# Altium schematic sheet STM32F407IGT6.SchDoc
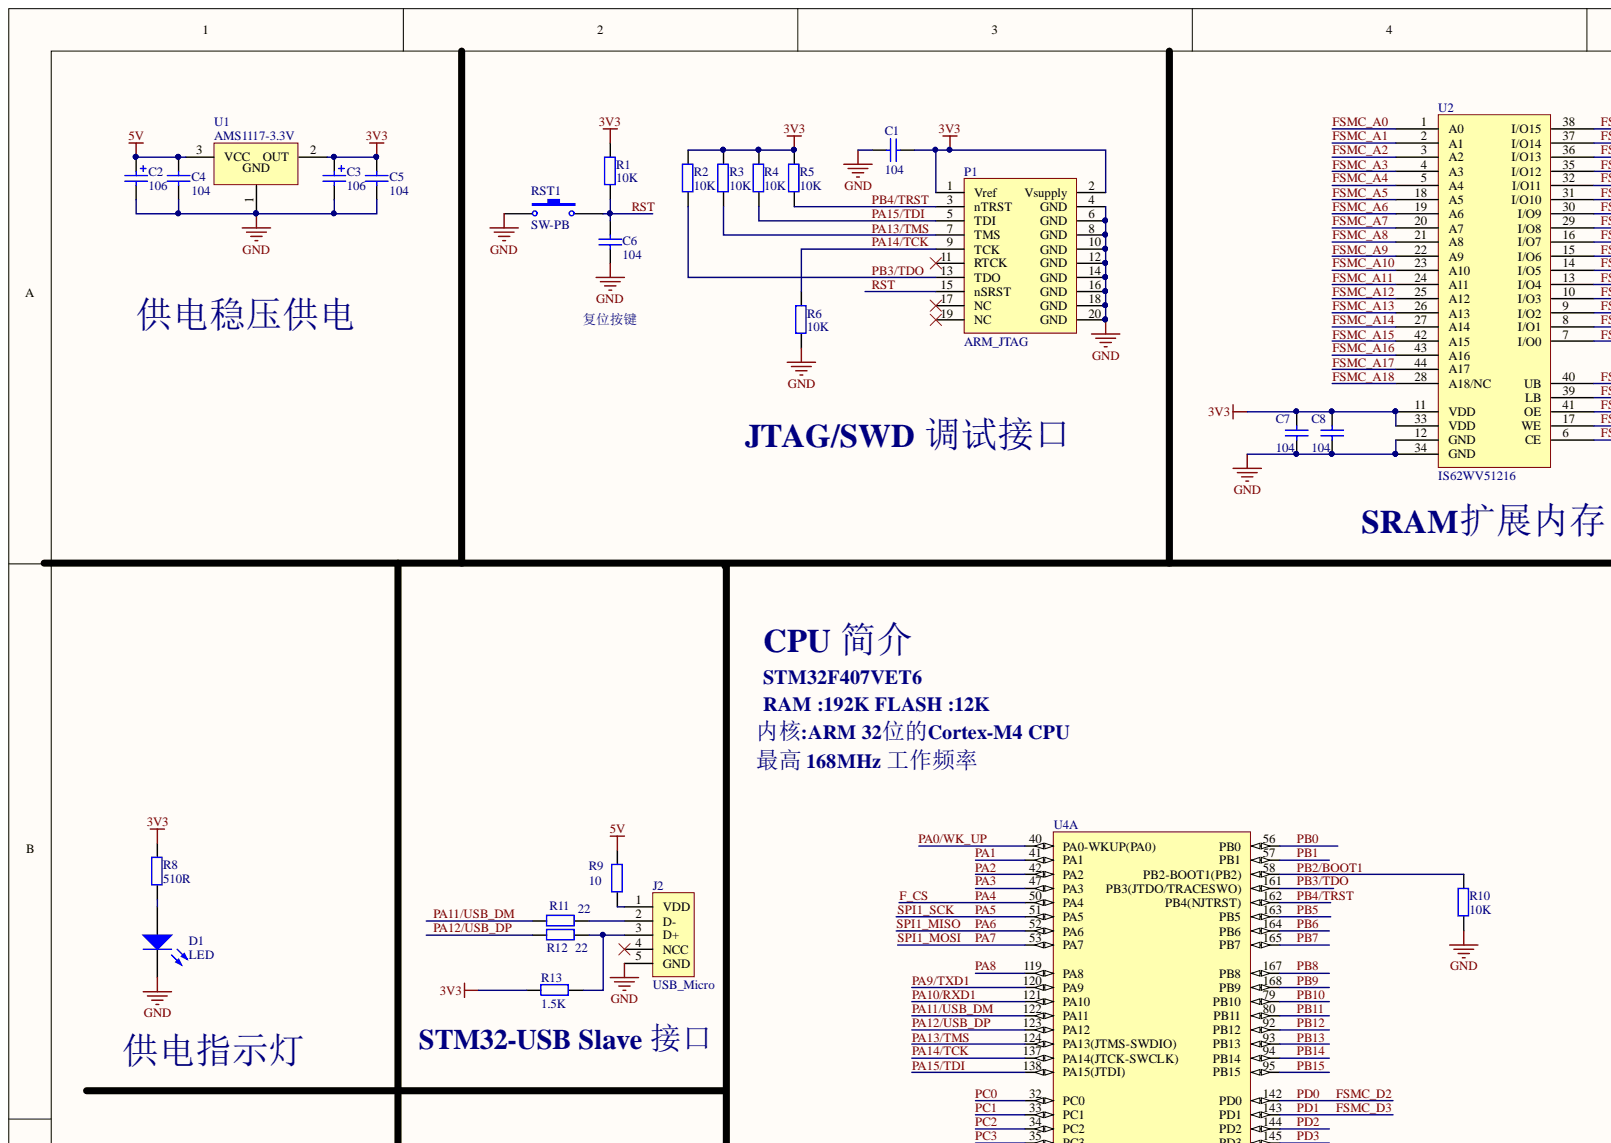
[diagram p0: STM32F407IGT6.SchDoc, top left]
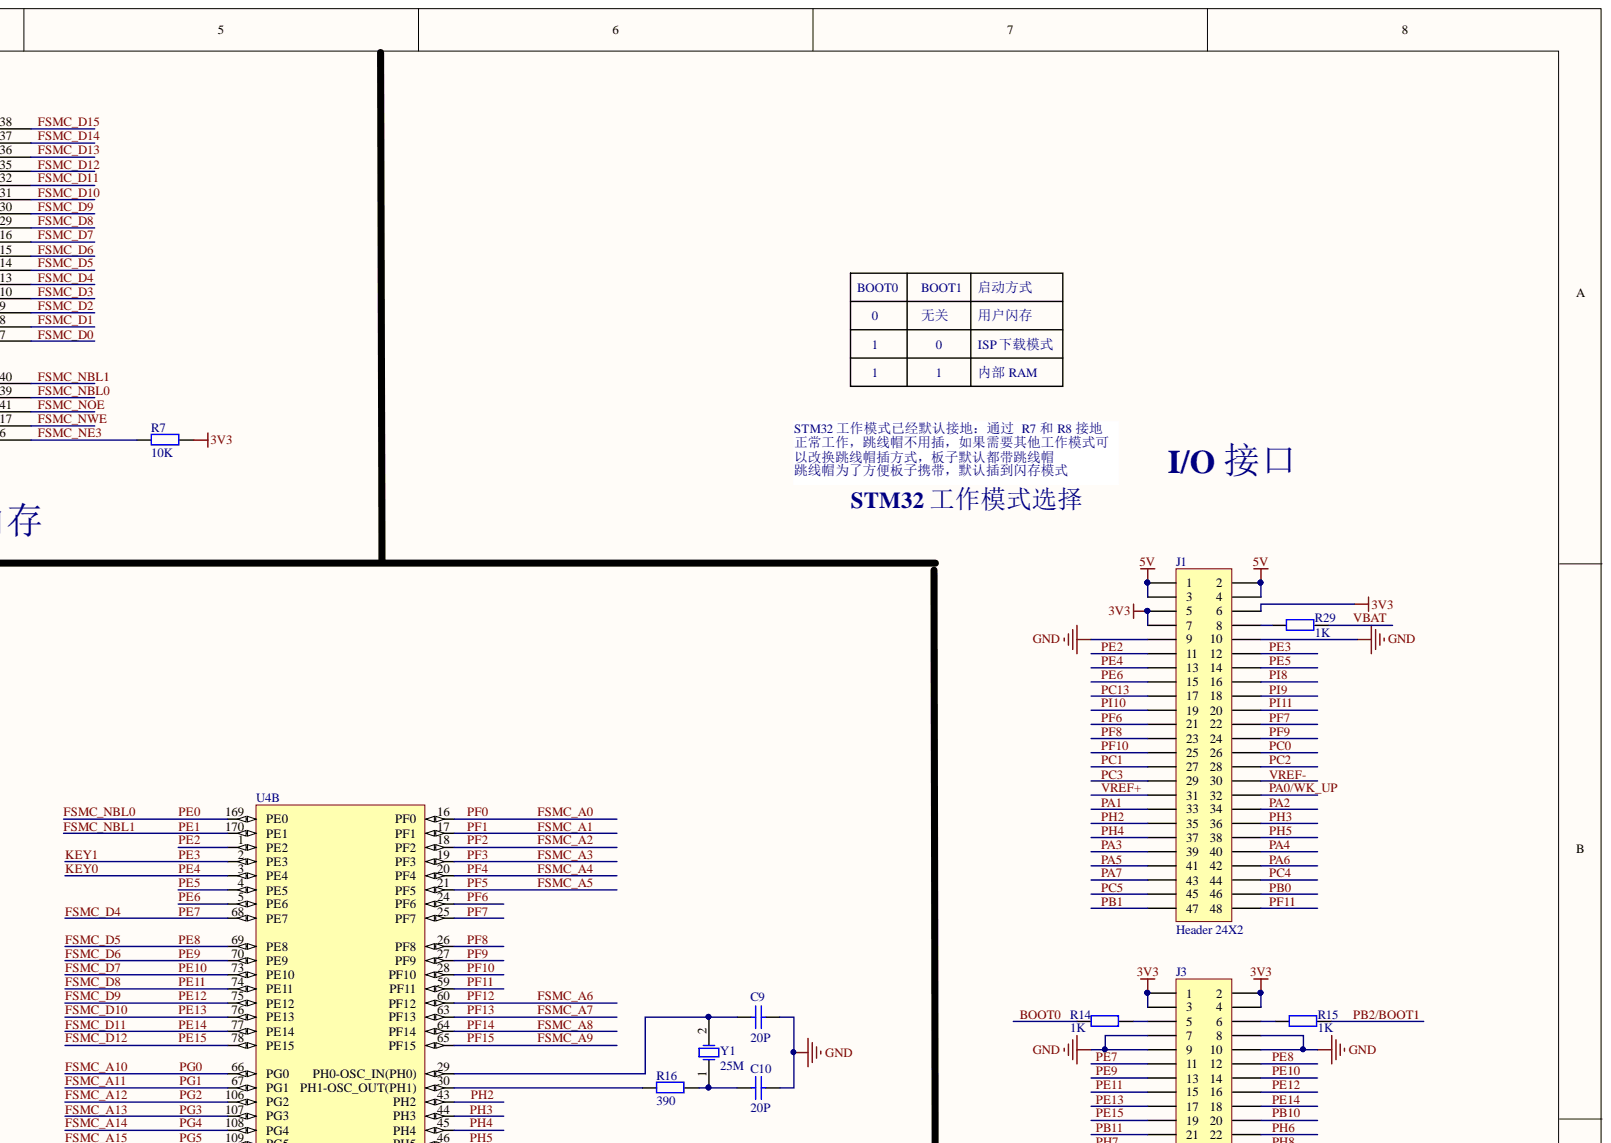
[diagram p1: STM32F407IGT6.SchDoc, top right]
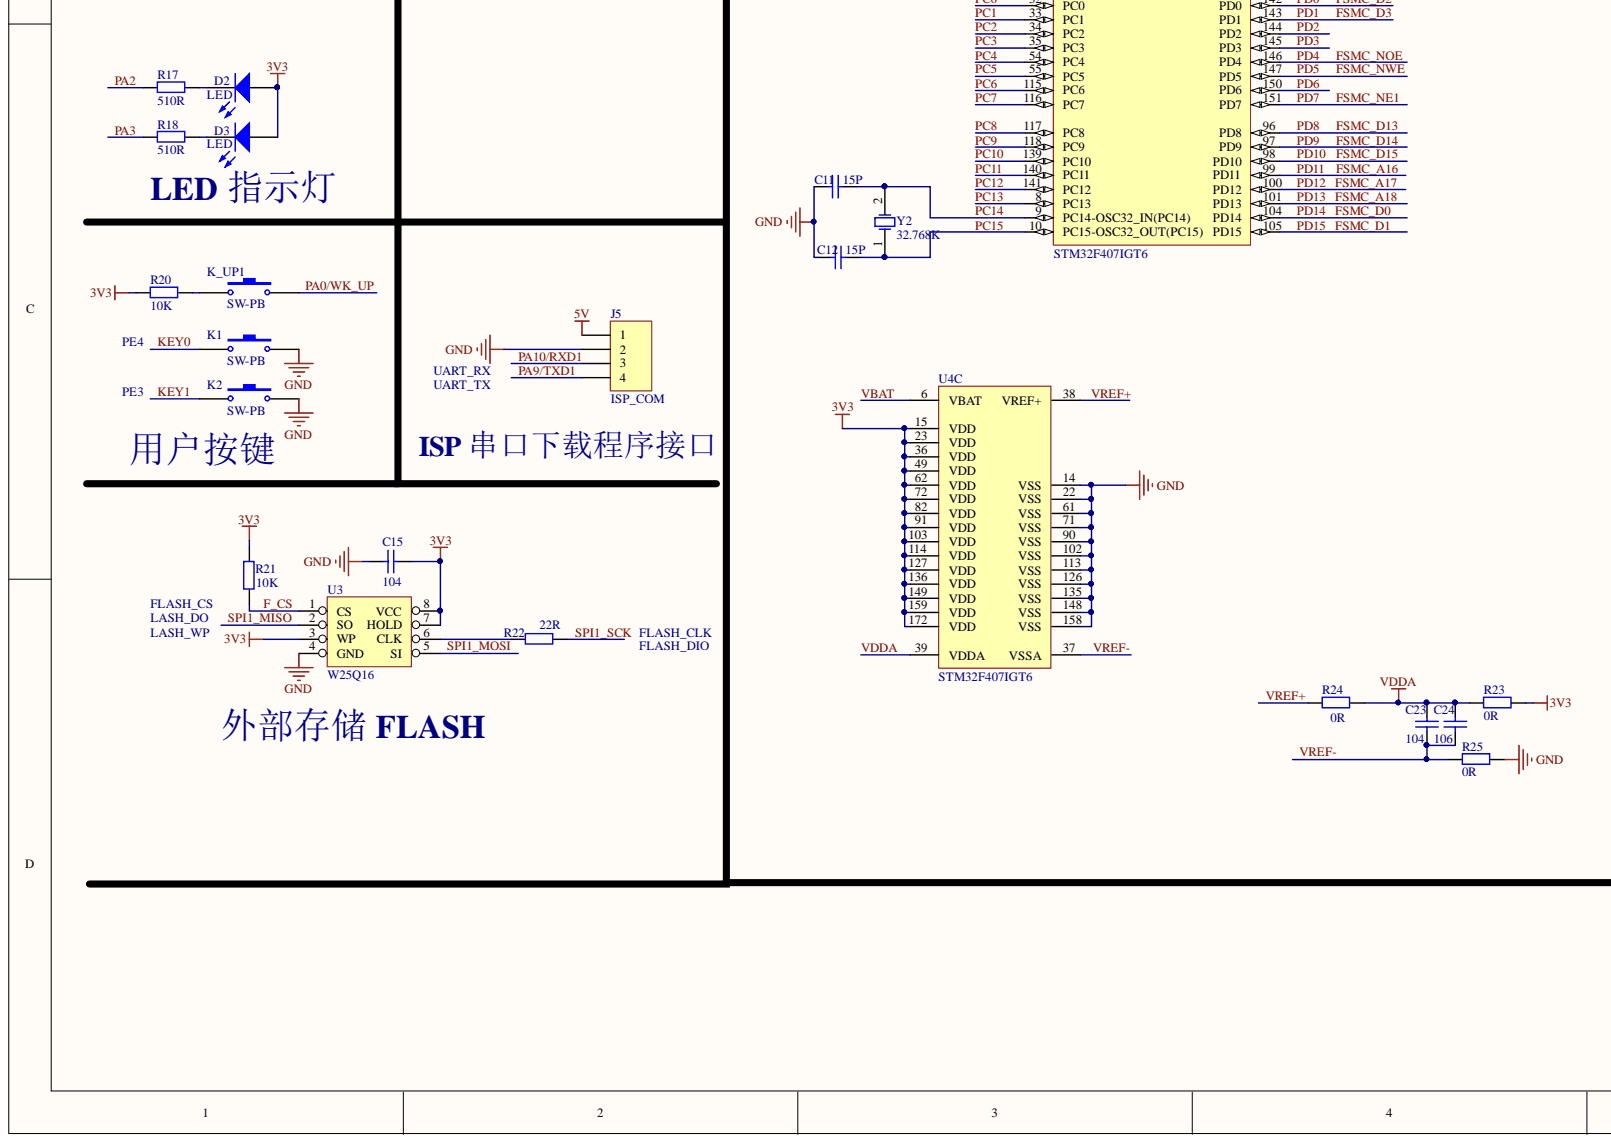
[diagram p2: STM32F407IGT6.SchDoc, bottom left]
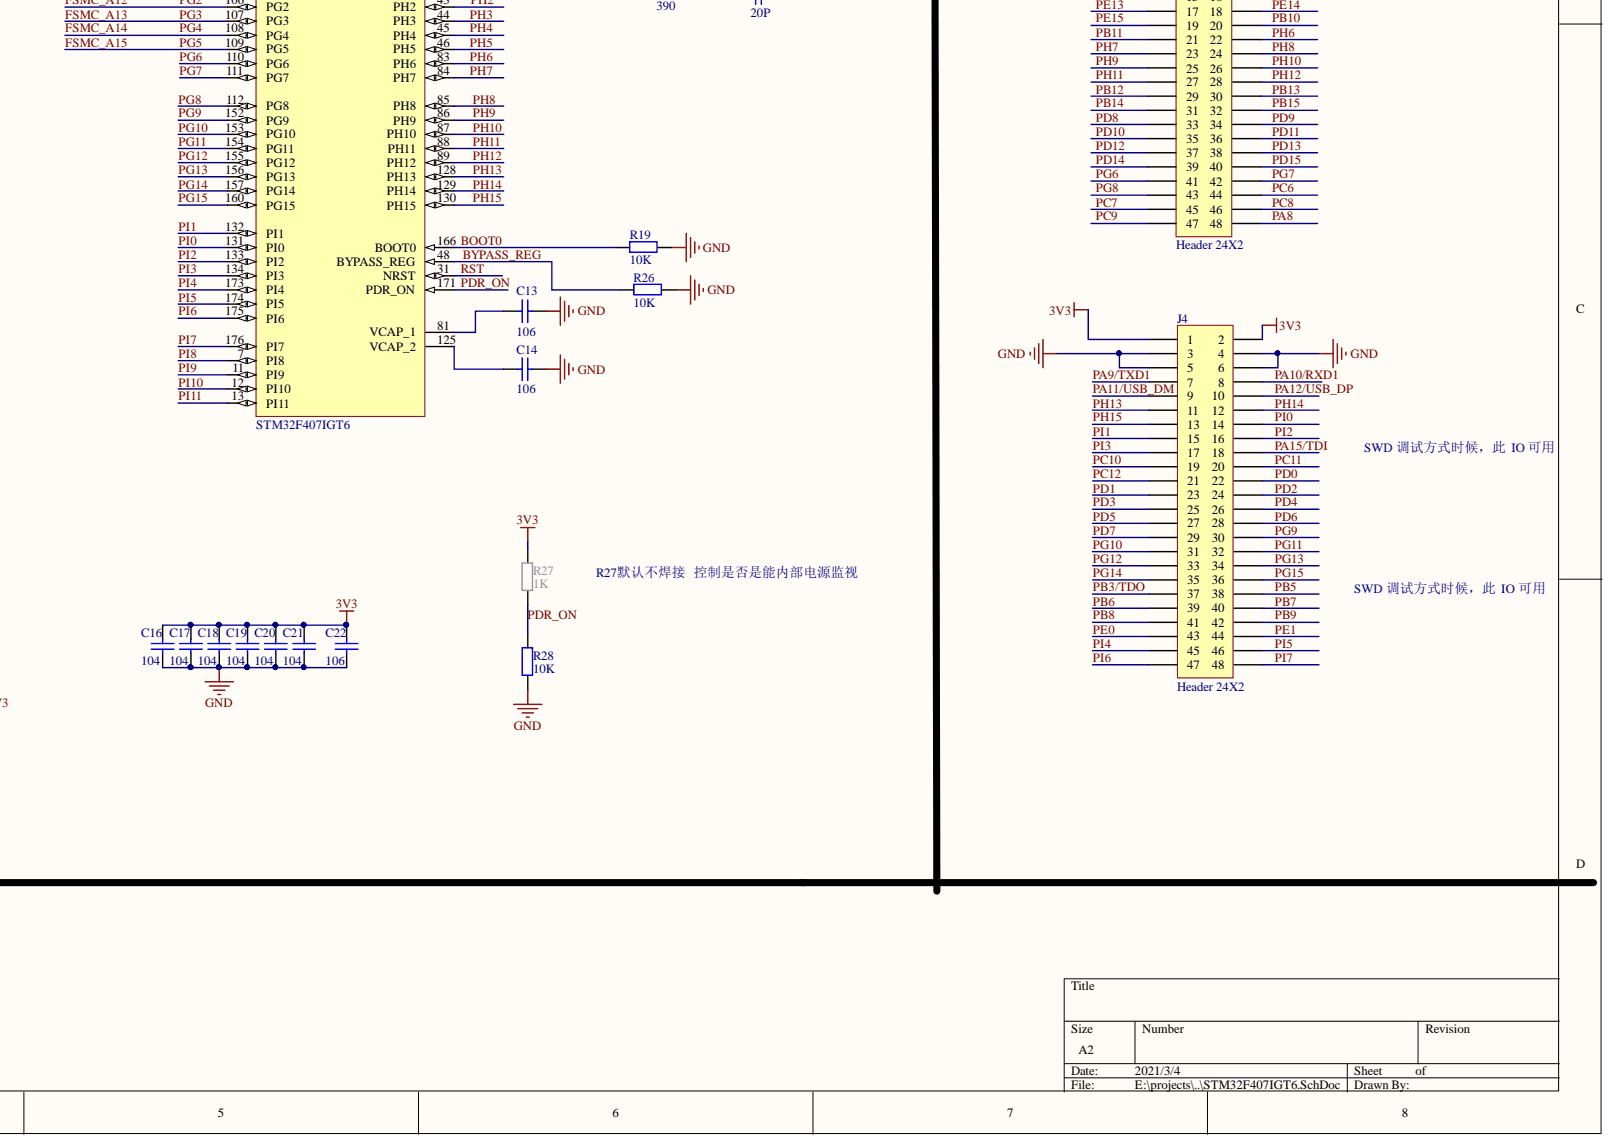
[diagram p3: STM32F407IGT6.SchDoc, bottom right]

## Parts
C1  104  [0603_c]
C2  106  [0603_c]
C3  106  [0603_c]
C4  104  [0603_c]
C5  104  [0603_c]
C6  104  [0603_c]
C7  104  [0603_c]
C8  104  [0603_c]
C9  20P  [0603_c]
C10  20P  [0603_c]
C11  15P  [0603_c]
C12  15P  [0603_c]
C13  106  [0603_c]
C14  106  [0603_c]
C15  104  [0603_c]
C16  104  [0603_c]
C17  104  [0603_c]
C18  104  [0603_c]
C19  104  [0603_c]
C20  104  [0603_c]
C21  104  [0603_c]
C22  106  [0603_c]
C23  104  [0603_c]
C24  106  [0603_c]
D1  LED  [LED0805]
D2  LED  [LED0805]
D3  LED  [LED0805]
J1  Header 24X2  [IDE2X24]
J2  USB_Micro  [USB_Micro]
J3  Header 24X2  [IDE2X24]
J4  Header 24X2  [IDE2X24]
J5  ISP_COM  [HDR1X4]
K1  SW-PB  [SW-SPST]
K2  SW-PB  [SW-SPST]
K_UP1  SW-PB  [SW-SPST]
P1  ARM_JTAG  [JTAG-20P]
R1  10K  [0603_r]
R2  10K  [0603_r]
R3  10K  [0603_r]
R4  10K  [0603_r]
R5  10K  [0603_r]
R6  10K  [0603_r]
R7  10K  [0603_r]
R8  510R  [0603_r]
R9  10  [0603_r]
R10  10K  [0603_r]
R11  22  [0603_r]
R12  22  [0603_r]
R13  1.5K  [0603_r]
R14  1K  [0603_r]
R15  1K  [0603_r]
R16  390  [0603_r]
R17  510R  [0603_r]
R18  510R  [0603_r]
R19  10K  [0603_r]
R20  10K  [0603_r]
R21  10K  [0603_r]
R22  22R  [0603_r]
R23  0R  [0603_r]
R24  0R  [0603_r]
R25  0R  [0603_r]
R26  10K  [0603_r]
R27  1K  [0603_r]
R28  10K  [0603_r]
R29  1K  [0603_r]
RST1  SW-PB  [SW-SPST]
U1  AMS1117-3.3V  [SOT-223]
U2  IS62WV51216  [IS62WV51216BLL-55TLI_ISI-M]
U3  W25Q16  [SOP8L]
U4  STM32F407IGT6  [STM-LQFP176_L]  (parts A,B,C)
Y1  25M  [49S_SMD]
Y2  32.768K  [3215_smd]

## Nets
3V3: C1.2 C3.1 C5.1 C7.1 C8.1 C15.2 C16.1 C17.1 C18.1 C19.1 C20.1 C21.1 C22.1 D2.1 D3.1 J1.5 J1.6 J1.7 J3.1 J3.2 J3.3 J3.4 J4.1 J4.2 P1.1(Vref) P1.2(Vsupply) R1.1 R2.1 R3.1 R4.1 R5.1 R7.1 R8.2 R13.2 R20.2 R21.1 R23.2 R27.2 U1.2 U2.11(VDD) U2.33(VDD) U3.3(WP) U3.7(HOLD) U3.8(VCC) U4.15(VDD) U4.23(VDD) U4.36(VDD) U4.49(VDD) U4.62(VDD) U4.72(VDD) U4.82(VDD) U4.91(VDD) U4.103(VDD) U4.114(VDD) U4.127(VDD) U4.136(VDD) U4.149(VDD) U4.159(VDD) U4.172(VDD)
5V: C2.1 C4.1 J1.1 J1.2 J1.3 J1.4 J5.1 R9.1 U1.3
GND: C1.1 C2.2 C3.2 C4.2 C5.2 C6.1 C7.2 C8.2 C9.2 C10.1 C11.1 C12.1 C13.1 C14.1 C15.1 C16.2 C17.2 C18.2 C19.2 C20.2 C21.2 C22.2 D1.2 J1.9 J1.10 J2.5(GND) J3.7 J3.8 J3.9 J3.10 J4.3 J4.4 J4.5 J4.6 J5.2 K1.2 K2.2 P1.4(GND) P1.6(GND) P1.8(GND) P1.10(GND) P1.12(GND) P1.14(GND) P1.16(GND) P1.18(GND) P1.20(GND) R6.1 R10.1 R19.1 R25.2 R26.1 R28.1 RST1.1 U1.1 U2.12(GND) U2.34(GND) U3.4(GND) U4.14(VSS) U4.22(VSS) U4.61(VSS) U4.71(VSS) U4.90(VSS) U4.102(VSS) U4.113(VSS) U4.126(VSS) U4.135(VSS) U4.148(VSS) U4.158(VSS)
BOOT0: R14.1 R19.2 U4.166(BOOT0)
BYPASS_REG: R26.2 U4.48(BYPASS_REG)
F_CS: J1.40 R21.2 U3.1(CS) U4.50(PA4)
FSMC_A0: U2.1(A0) U4.16(PF0)
FSMC_A1: U2.2(A1) U4.17(PF1)
FSMC_A2: U2.3(A2) U4.18(PF2)
FSMC_A3: U2.4(A3) U4.19(PF3)
FSMC_A4: U2.5(A4) U4.20(PF4)
FSMC_A5: U2.18(A5) U4.21(PF5)
FSMC_A6: U2.19(A6) U4.60(PF12)
FSMC_A7: U2.20(A7) U4.63(PF13)
FSMC_A8: U2.21(A8) U4.64(PF14)
FSMC_A9: U2.22(A9) U4.65(PF15)
FSMC_A10: U2.23(A10) U4.66(PG0)
FSMC_A11: U2.24(A11) U4.67(PG1)
FSMC_A12: U2.25(A12) U4.106(PG2)
FSMC_A13: U2.26(A13) U4.107(PG3)
FSMC_A14: U2.27(A14) U4.108(PG4)
FSMC_A15: U2.42(A15) U4.109(PG5)
FSMC_A16: J3.36 U2.43(A16) U4.99(PD11)
FSMC_A17: J3.37 U2.44(A17) U4.100(PD12)
FSMC_A18: J3.38 U2.28(A18/NC) U4.101(PD13)
FSMC_D0: J3.39 U2.7(I/O0) U4.104(PD14)
FSMC_D1: J3.40 U2.8(I/O1) U4.105(PD15)
FSMC_D2: J4.22 U2.9(I/O2) U4.142(PD0)
FSMC_D3: J4.23 U2.10(I/O3) U4.143(PD1)
FSMC_D4: J3.11 U2.13(I/O4) U4.68(PE7)
FSMC_D5: J3.12 U2.14(I/O5) U4.69(PE8)
FSMC_D6: J3.13 U2.15(I/O6) U4.70(PE9)
FSMC_D7: J3.14 U2.16(I/O7) U4.73(PE10)
FSMC_D8: J3.15 U2.29(I/O8) U4.74(PE11)
FSMC_D9: J3.16 U2.30(I/O9) U4.75(PE12)
FSMC_D10: J3.17 U2.31(I/O10) U4.76(PE13)
FSMC_D11: J3.18 U2.32(I/O11) U4.77(PE14)
FSMC_D12: J3.19 U2.35(I/O12) U4.78(PE15)
FSMC_D13: J3.33 U2.36(I/O13) U4.96(PD8)
FSMC_D14: J3.34 U2.37(I/O14) U4.97(PD9)
FSMC_D15: J3.35 U2.38(I/O15) U4.98(PD10)
FSMC_NBL0: J4.43 U2.39(LB) U4.169(PE0)
FSMC_NBL1: J4.44 U2.40(UB) U4.170(PE1)
FSMC_NE1: J4.29 U4.151(PD7)
FSMC_NE3: R7.2 U2.6(CE)
FSMC_NOE: J4.26 U2.41(OE) U4.146(PD4)
FSMC_NWE: J4.27 U2.17(WE) U4.147(PD5)
KEY0: J1.13 K1.1 U4.3(PE4)
KEY1: J1.12 K2.1 U4.2(PE3)
PA0/WK_UP: J1.32 K_UP1.2 U4.40(PA0-WKUP(PA0))
PA1: J1.33 U4.41(PA1)
PA2: J1.34 R17.1 U4.42(PA2)
PA3: J1.39 R18.1 U4.47(PA3)
PA5: J1.41 R22.2 U4.51(PA5)
PA6: J1.42 U3.2(SO) U4.52(PA6)
PA7: J1.43 U3.5(SI) U4.53(PA7)
PA8: J3.48 U4.119(PA8)
PA9/TXD1: J4.7 J5.4 U4.120(PA9)
PA10/RXD1: J4.8 J5.3 U4.121(PA10)
PA11/USB_DM: J4.9 R11.2 U4.122(PA11)
PA12/USB_DP: J4.10 R12.2 U4.123(PA12)
PA13/TMS: P1.7(TMS) R3.2 U4.124(PA13(JTMS-SWDIO))
PA14/TCK: P1.9(TCK) R6.2 U4.137(PA14(JTCK-SWCLK))
PA15/TDI: J4.18 P1.5(TDI) R4.2 U4.138(PA15(JTDI))
PB0: J1.46 U4.56(PB0)
PB1: J1.47 U4.57(PB1)
PB2/BOOT1: R10.2 R15.2 U4.58(PB2-BOOT1(PB2))
PB3/TDO: J4.37 P1.13(TDO) R2.2 U4.161(PB3(JTDO/TRACESWO))
PB4/TRST: P1.3(nTRST) R5.2 U4.162(PB4(NJTRST))
PB5: J4.38 U4.163(PB5)
PB6: J4.39 U4.164(PB6)
PB7: J4.40 U4.165(PB7)
PB8: J4.41 U4.167(PB8)
PB9: J4.42 U4.168(PB9)
PB10: J3.20 U4.79(PB10)
PB11: J3.21 U4.80(PB11)
PB12: J3.29 U4.92(PB12)
PB13: J3.30 U4.93(PB13)
PB14: J3.31 U4.94(PB14)
PB15: J3.32 U4.95(PB15)
PC0: J1.26 U4.32(PC0)
PC1: J1.27 U4.33(PC1)
PC2: J1.28 U4.34(PC2)
PC3: J1.29 U4.35(PC3)
PC4: J1.44 U4.54(PC4)
PC5: J1.45 U4.55(PC5)
PC6: J3.44 U4.115(PC6)
PC7: J3.45 U4.116(PC7)
PC8: J3.46 U4.117(PC8)
PC9: J3.47 U4.118(PC9)
PC10: J4.19 U4.139(PC10)
PC11: J4.20 U4.140(PC11)
PC12: J4.21 U4.141(PC12)
PC13: J1.17 U4.8(PC13)
PC14: C11.2 U4.9(PC14-OSC32_IN(PC14)) Y2.2
PC15: C12.2 U4.10(PC15-OSC32_OUT(PC15)) Y2.1
PD2: J4.24 U4.144(PD2)
PD3: J4.25 U4.145(PD3)
PD6: J4.28 U4.150(PD6)
PDR_ON: R27.1 R28.2 U4.171(PDR_ON)
PE2: J1.11 U4.1(PE2)
PE5: J1.14 U4.4(PE5)
PE6: J1.15 U4.5(PE6)
PF6: J1.21 U4.24(PF6)
PF7: J1.22 U4.25(PF7)
PF8: J1.23 U4.26(PF8)
PF9: J1.24 U4.27(PF9)
PF10: J1.25 U4.28(PF10)
PF11: J1.48 U4.59(PF11)
PG6: J3.41 U4.110(PG6)
PG7: J3.42 U4.111(PG7)
PG8: J3.43 U4.112(PG8)
PG9: J4.30 U4.152(PG9)
PG10: J4.31 U4.153(PG10)
PG11: J4.32 U4.154(PG11)
PG12: J4.33 U4.155(PG12)
PG13: J4.34 U4.156(PG13)
PG14: J4.35 U4.157(PG14)
PG15: J4.36 U4.160(PG15)
PH2: J1.35 U4.43(PH2)
PH3: J1.36 U4.44(PH3)
PH4: J1.37 U4.45(PH4)
PH5: J1.38 U4.46(PH5)
PH6: J3.22 U4.83(PH6)
PH7: J3.23 U4.84(PH7)
PH8: J3.24 U4.85(PH8)
PH9: J3.25 U4.86(PH9)
PH10: J3.26 U4.87(PH10)
PH11: J3.27 U4.88(PH11)
PH12: J3.28 U4.89(PH12)
PH13: J4.11 U4.128(PH13)
PH14: J4.12 U4.129(PH14)
PH15: J4.13 U4.130(PH15)
PI0: J4.14 U4.131(PI0)
PI1: J4.15 U4.132(PI1)
PI2: J4.16 U4.133(PI2)
PI3: J4.17 U4.134(PI3)
PI4: J4.45 U4.173(PI4)
PI5: J4.46 U4.174(PI5)
PI6: J4.47 U4.175(PI6)
PI7: J4.48 U4.176(PI7)
PI8: J1.16 U4.7(PI8)
PI9: J1.18 U4.11(PI9)
PI10: J1.19 U4.12(PI10)
PI11: J1.20 U4.13(PI11)
RST: C6.2 P1.15(nSRST) R1.2 RST1.2 U4.31(NRST)
VBAT: R29.2 U4.6(VBAT)
VDDA: C23.1 C24.1 R23.1 R24.2 U4.39(VDDA)
VREF+: J1.31 R24.1 U4.38(VREF+)
VREF-: C23.2 C24.2 J1.30 R25.1 U4.37(VSSA)
NetC9_1: C9.1 U4.29(PH0-OSC_IN(PH0)) Y1.2
NetC10_2: C10.2 R16.1 Y1.1
NetC13_2: C13.2 U4.81(VCAP_1)
NetC14_2: C14.2 U4.125(VCAP_2)
NetD1_1: D1.1 R8.1
NetD2_2: D2.2 R17.2
NetD3_2: D3.2 R18.2
NetJ1_8: J1.8 R29.1
NetJ2_1: J2.1(VDD) R9.2
NetJ2_2: J2.2(D-) R11.1
NetJ2_3: J2.3(D+) R12.1 R13.1
NetJ3_5: J3.5 R14.2
NetJ3_6: J3.6 R15.1
NetK_UP1_1: K_UP1.1 R20.1
NetR16_2: R16.2 U4.30(PH1-OSC_OUT(PH1))
NetR22_1: R22.1 U3.6(CLK)

Not connected: J2 4(NCC); P1 11(RTCK) 17(NC) 19(NC)

## Library data of the parts
C1 C4-C24 = Cap: Capacitor; Value: 100pF; Component Kind: Standard; LatestRevisionDate: 17-Jul-2002; LatestRevisionNote: Re-released for DXP Platform.; Library Name: Miscellaneous Devices.IntLib; PackageDescription: Radial Cap, Thru-Hole; 2 Leads; 0.3 in Pin Spacing; PackageReference: RAD-0.3; Published: 8-Jun-2000; Signal Integrity: Cap; Simulation: CAP; Publisher: Altium Limited
C2 C3 = Cap Pol2: Polarized Capacitor (Axial); Value: 10u; Component Kind: Standard; LatestRevisionDate: 17-Jul-2002; LatestRevisionNote: Re-released for DXP Platform.; Library Name: Miscellaneous Devices.IntLib; PackageDescription: Polarized Capacitor; 2 Leads; PackageReference: POLAR0.8; Published: 8-Jun-2000; Signal Integrity: Cap Pol; Simulation: CAP; Publisher: Altium Limited
D1-D3 = LED2: Typical RED, GREEN, YELLOW, AMBER GaAs LED; Component Kind: Standard; LatestRevisionDate: 17-Jul-2002; LatestRevisionNote: Re-released for DXP Platform.; Library Name: Miscellaneous Devices.IntLib; PackageDescription: SM LED; 2 Flat Leads; PackageReference: 3.2X1.6X1.1; PackageVersion: Feb-2002; Published: 8-Jun-2000; Publisher: Altium Limited
  D2 D3: Simulation: LED2
J1 J3 J4 = Header 24X2: Header, 24-Pin, Dual row; Component Kind: Standard; LatestRevisionDate: 17-Jul-2002; LatestRevisionNote: Re-released for DXP Platform.; Library Name: Miscellaneous Connectors.IntLib; Signal Integrity: Connector; Publisher: Altium Limited
J5 = Header 4: Header, 4-Pin; Component Kind: Standard; LatestRevisionDate: 17-Jul-2002; LatestRevisionNote: Re-released for DXP Platform.; Library Name: Miscellaneous Connectors.IntLib; Signal Integrity: Connector; Publisher: Altium Limited
K1 K2 K_UP1 RST1 = SW-PB: Switch; Component Kind: Standard; LatestRevisionDate: 17-Jul-2002; LatestRevisionNote: Re-released for DXP Platform.; Library Name: Miscellaneous Devices.IntLib; PackageDescription: Switch; 2 Leads; PackageReference: SPST-2; Published: 24-Mar-1999; Publisher: Altium Limited
P1 = ARM_JTAG/SWD: Component Kind: Standard; Description: ARM_JTAG/SWD; Library Name: STM32F407VET6.SchLib
R1-R13 R16-R26 R28 = Res2: Resistor; Value: 1K; Component Kind: Standard; LatestRevisionDate: 17-Jul-2002; LatestRevisionNote: Re-released for DXP Platform.; Library Name: Miscellaneous Devices.IntLib; PackageDescription: Axial Device, Thru-Hole; 2 Leads; 0.4 in Pin Spacing; PackageReference: AXIAL-0.4; Published: 8-Jun-2000; Signal Integrity: Res; Simulation: RESISTOR; Publisher: Altium Limited
R14 R15 R27 R29 = Res2: Resistor; Component Kind: Standard; LatestRevisionDate: 17-Jul-2002; LatestRevisionNote: Re-released for DXP Platform.; Library Name: Miscellaneous Devices.IntLib; PackageDescription: Axial Device, Thru-Hole; 2 Leads; 0.4 in Pin Spacing; PackageReference: AXIAL-0.4; Published: 8-Jun-2000; Signal Integrity: Res; Simulation: RESISTOR; Value: 1K; Publisher: Altium Limited
U3 = W25Q16: 3V 16Mbit Serial Flash Memory with dual/quad SPI; Component Kind: Standard; Library Name: STM32F407VET6.SchLib
U4 = STM32F407IGT6: ARM Cortex-M4 32-bit MCU+FPU, 210 DMIPS, 1024 kB Flash, 192 kB Internal RAM, 140 I/Os, 176-pin LQFP, -40 to 85 degC, Tray; Manufacturer: STMicroelectronics; D/A Converter: 2x12-bit; FLASH Size (Prog) (kB): 1024; I/Os (High Current): 140; Internal RAM Size (kB): 192; Operating Frequency(F) (Processor speed) (MHz): 168; Supply Voltage(Vcc) max (V): 3.6; Supply Voltage(Vcc) min (V): 1.8; Component Kind: Standard; ComponentLink1Description: Manufacturer URL; ComponentLink1URL: http://www.st.com/web/en/home.html; ComponentLink2Description: Datasheet; ComponentLink2URL: http://www.st.com/st-web-ui/static/active/en/resource/technical/document/datasheet/DM00037051.pdf; ComponentLink3Description: Package Specification; ComponentLink3URL: http://www.st.com/st-web-ui/static/active/en/resource/technical/document/datasheet/DM00035129.pdf; Core: ARM Cortex-M4; DatasheetVersion: Rev. 4; Library Name: STMicroelectronics STM32 F4.SchLib; Mounting Technology: Surface Mount; PackageDescription: 176-Pin Low Profile Quad Flat Package, 24 x 24 mm Body, 0.5 mm Pitch; PackageReference: LQFP176; PackageVersion: Rev. 4, 06/2013; Packing: Tray; PartNumber: STM32F407IGT6; Supplier 1: Digi-Key; Supplier 2: Farnell; Supplier 3: Newark; Supplier 4: Mouser; Supplier Part Number 1: 497-11604-ND; Supplier Part Number 2: 2064366; Supplier Part Number 3: 73T2331; Supplier Part Number 4: 511-STM32F407IGT6
Y1 Y2 = XTAL: Crystal Oscillator; Component Kind: Standard; LatestRevisionDate: 17-Jul-2002; LatestRevisionNote: Re-released for DXP Platform.; Library Name: Miscellaneous Devices.IntLib; PackageDescription: Crystal, Thru-Hole; 2 Leads; Body 3.1 x 8.2 mm (Dia.xH); PackageReference: R38; Published: 8-Jun-2000; Publisher: Altium Limited
J2 = USB_Micro: Component Kind: Standard; Description: USB_Micro; Library Name: STM32F407VET6.SCHLIB
U1 = AMS1117-3.3V: Component Kind: Standard; Description: AMS1117-3.3V; Library Name: STM32F407VET6.SchLib
U2 = IS62WV51216: Component Kind: Standard; Library Name: T3_Devices.IntLib
